# Altium schematic sheet ASV3.5-2 54V Isolator.SchDoc("ASV3.5-2 54V Isolator")
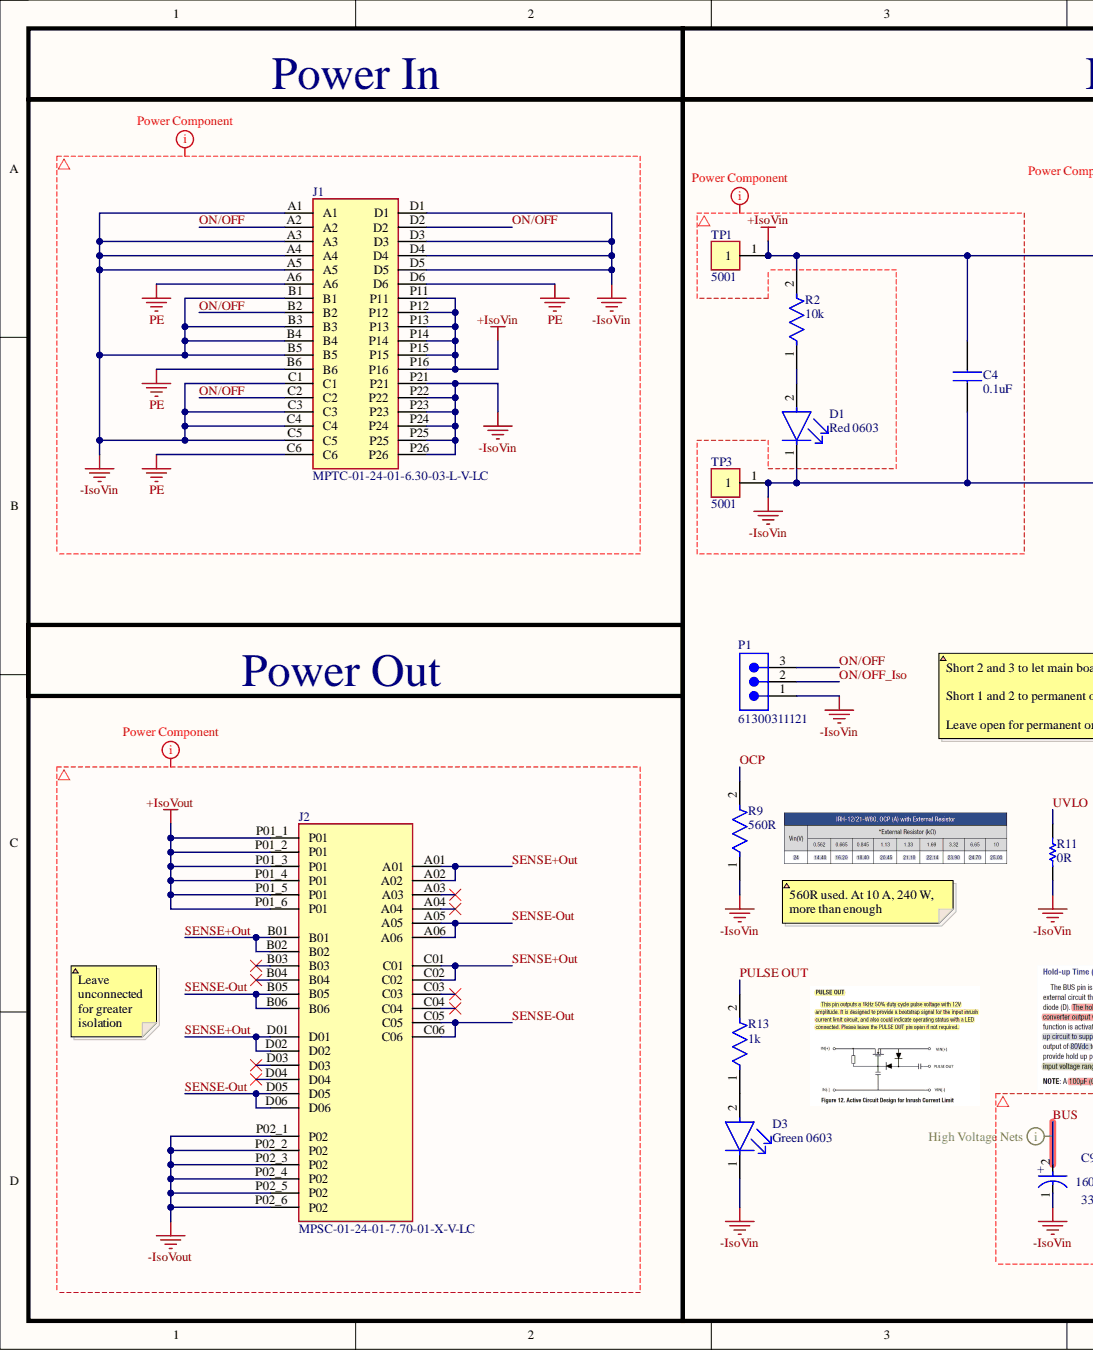
[diagram p0: ASV3.5-2 54V Isolator.SchDoc, left]
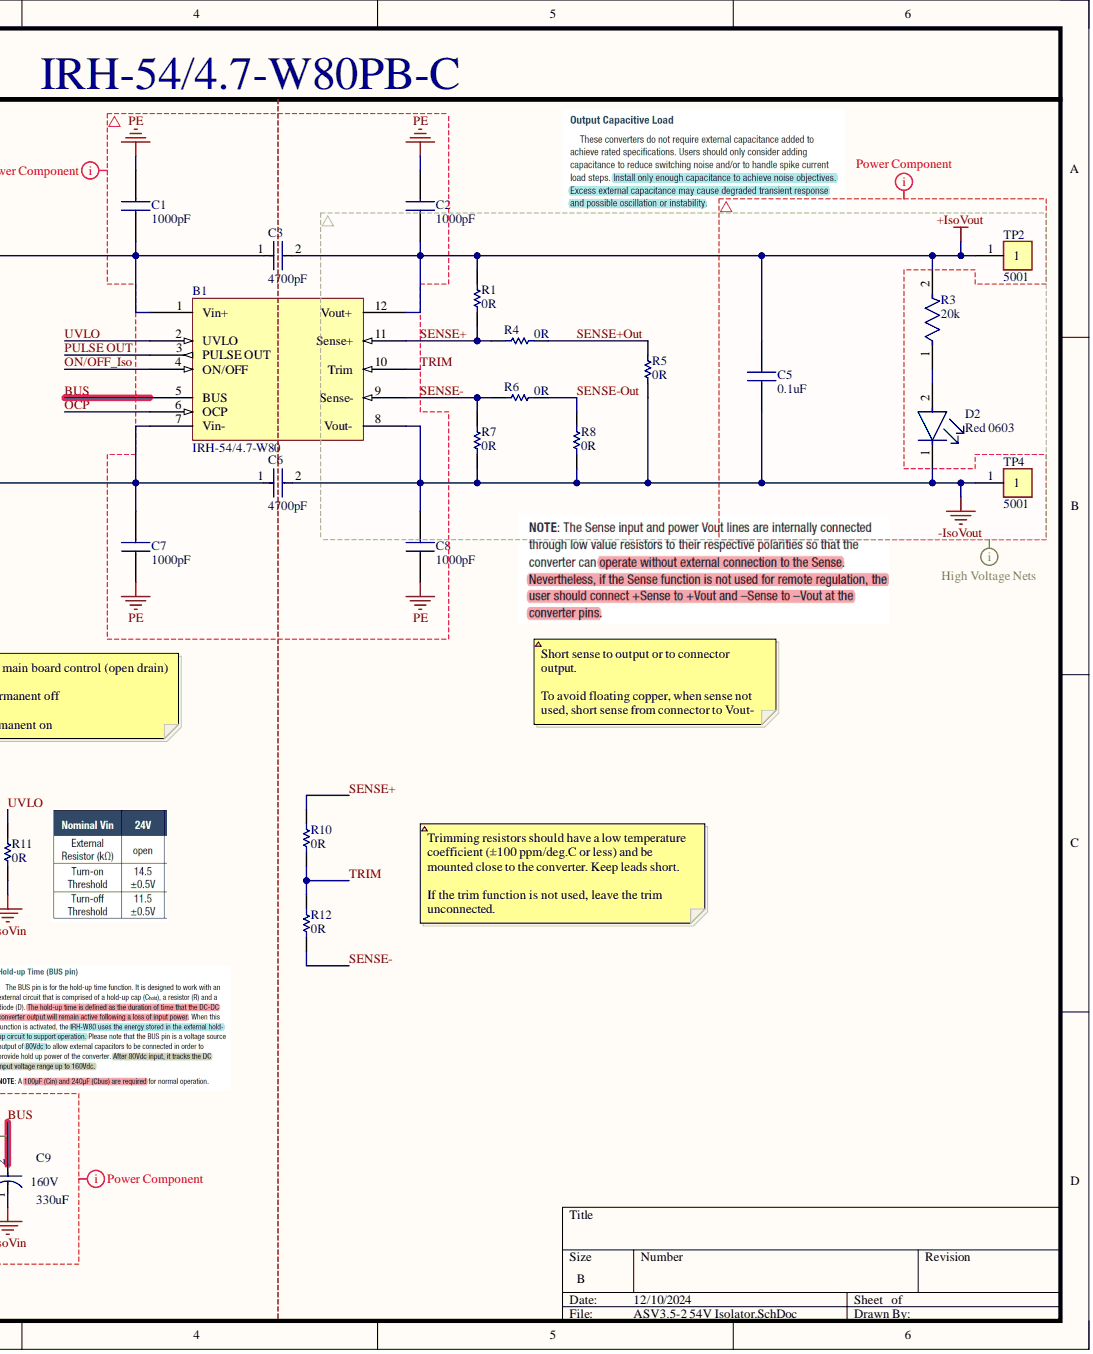
[diagram p1: ASV3.5-2 54V Isolator.SchDoc, right]

## Parts
B1  IRH-54/4.7-W80
C1  1000pF
C2  1000pF
C3  4700pF
C4  0.1uF
C5  0.1uF
C6  4700pF
C7  1000pF
C8  1000pF
C9  330uF  Voltage: 160V
D1  Red 0603  Case Code (Imperial): 0603  Color: Red
D2  Red 0603  Case Code (Imperial): 0603  Color: Red
D3  Green 0603  Color: Green
J1  MPTC-01-24-01-6.30-03-L-V-LC
J2  MPSC-01-24-01-7.70-01-X-V-LC
P1  61300311121
R1  0R
R2  10k
R3  20k
R4  0R
R5  0R
R6  0R
R7  0R
R8  0R
R9  560R
R10  0R
R11  0R
R12  0R
R13  1k
TP1  5001
TP2  5001
TP3  5001
TP4  5001

## Nets
+IsoVin: B1.1(Vin+) C1.1 C3.1 C4.2 J1.P11 J1.P12 J1.P13 J1.P14 J1.P15 J1.P16 R2.2 TP1.1
+IsoVout: B1.12(Vout+) C2.1 C3.2 C5.2 J2.P01_1(P01) J2.P01_2(P01) J2.P01_3(P01) J2.P01_4(P01) J2.P01_5(P01) J2.P01_6(P01) R1.2 R3.2 TP2.1
-IsoVin: B1.7(Vin-) C4.1 C6.1 C7.2 C9.1 D1.1 D3.1 J1.A1 J1.A3 J1.A4 J1.A5 J1.B1 J1.B3 J1.B4 J1.B5 J1.C1 J1.C3 J1.C4 J1.C5 J1.D1 J1.D3 J1.D4 J1.D5 J1.P21 J1.P22 J1.P23 J1.P24 J1.P25 J1.P26 P1.1 R9.1 R11.2 TP3.1
-IsoVout: B1.8(Vout-) C5.1 C6.2 C8.2 D2.1 J2.P02_1(P02) J2.P02_2(P02) J2.P02_3(P02) J2.P02_4(P02) J2.P02_5(P02) J2.P02_6(P02) R5.1 R7.2 R8.1 TP4.1
PE: C1.2 C2.2 C7.1 C8.1 J1.A6 J1.B6 J1.C6 J1.D6
BUS: B1.5(BUS) C9.2
OCP: B1.6(OCP) R9.2
ON/OFF: J1.A2 J1.B2 J1.C2 J1.D2 P1.3
ON/OFF_Iso: B1.4(ON/OFF) P1.2
PULSE OUT: B1.3(PULSE_OUT) R13.2
SENSE+: B1.11(Sense+) R1.1 R4.1 R10.1
SENSE+Out: J2.A01 J2.A02 J2.B01 J2.B02 J2.C01 J2.C02 J2.D01 J2.D02 R4.2 R5.2
SENSE-: B1.9(Sense-) R6.1 R7.1 R12.2
SENSE-Out: J2.A05 J2.A06 J2.B05 J2.B06 J2.C05 J2.C06 J2.D05 J2.D06 R6.2 R8.2
TRIM: B1.10(Trim) R10.2 R12.1
UVLO: B1.2(UVLO) R11.1
NetD1_2: D1.2 R2.1
NetD2_2: D2.2 R3.1
NetD3_2: D3.2 R13.1

Not connected: J2 A03 A04 B03 B04 C03 C04 D03 D04

## Library data of the parts
B1 = CMP-00062-2: Murata IRH-54/4.7-W80, 16-160VDC to 54VDC 4.7A, 250W,  isolated regulated converter; Component Kind: Standard; ComponentLink1Description: element14; ComponentLink1URL: https://sg.element14.com/murata-power-solutions/irh-54-4-7-w80pb-c/dc-dc-converter-54v-4-7a/dp/4132834?CMP=i-55c5-00001621; ComponentLink2Description: IRH-54/4.7-W80; ComponentLink2URL: https://www.farnell.com/datasheets/3625925.pdf; Library Name: BumbleBee Autonomous Systems - NUS
C1 C2 C7 C8 = CMP-123-000008-2: GR442QR73D102KW01L. MURATA - GR442QR73D102KW01L - CAPACITOR, MLCC, X7R, 1000PF, 2KV, 1808; Manufacturer_Name: Murata Electronics; Geometry.Height: 1.5mm; Component Kind: Standard; Datasheet Link: https://componentsearchengine.com/Datasheets/2/GR442QR73D102KW01L.pdf; Design Item ID: GR442QR73D102KW01L; Manufacturer_Part_Number: GR442QR73D102KW01L; Mouser Part Number: 81-GR442QR73D102KW01; Mouser Price/Stock: https://www.mouser.co.uk/ProductDetail/Murata-Electronics/GR442QR73D102KW01L?qs=1XGAtBqYNz74JcxvF1DQuw%3D%3D; Source: GR442QR73D102KW01L.SchLib; Library Name: BumbleBee Autonomous Systems - NUS
C3 C6 = CMP-06035-046982-1: DE1E3RA472MA4BQ01F. Cap Ceramic Disc 4700pF 20% 500VAC Thru-Hole 14.0x6.0x10.0 Bulk; Component Kind: Standard; Library Name: Altium Content Vault
C4 C5 = CMP-123-000009-2: GRM32DR72H104KW10L. Multilayer Ceramic Capacitors MLCC - SMD/SMT 1210 0.1uF 500volt X7R 10%; Manufacturer_Name: Murata Electronics; Geometry.Height: 2.2mm; Component Kind: Standard; Datasheet Link: https://componentsearchengine.com/Datasheets/1/GRM32DR72H104KW10L.pdf; Design Item ID: GRM32DR72H104KW10L; Manufacturer_Part_Number: GRM32DR72H104KW10L; Mouser Part Number: 81-GRM32DR72H104KW1L; Mouser Price/Stock: https://www.mouser.com/Search/Refine.aspx?Keyword=81-GRM32DR72H104KW1L; Source: GRM32DR72H104KW10L.SchLib; Library Name: BumbleBee Autonomous Systems - NUS
C9 = CMP-05427-000720-1: EEUEE2C331. Aluminum Electrolytic Capacitors (Radial Lead Type) 330uF ±20% 160V; Dissipation Factor: 0.15%; Lead Diameter: 0.8mm; Leakage Current: 3.178mA; Life (Hours): 10000Hour; Polarity: Polar; Ripple Current: 2.6A; Capacitance: 330uF; Case/Package: Radial; Component Kind: Standard; Construction: RADIAL; Contact Plating: Tin; Diameter: 18mm; Height: 31.5mm; Height - Seated (Max): 1.319inch; Lead Free: Lead Free; Lead Pitch: 7.5mm; Length: 31.5mm; Library Name: Altium Content Vault; Max Operating Temperature: 105°C; Min Operating Temperature: -25°C; Mount: Through Hole; Number of Pins: 2; Package Quantity: 50; Packaging: Bulk; Radiation Hardening: No; REACH SVHC: No SVHC; Ripple Current (AC): 2.6A; RoHS Compliant: Yes; Series: EE; Termination: Radial; Tolerance: 20%; Voltage Rating: 160V; Voltage Rating (DC): 160V; Weight: 0.01lb; Width: 0.5inch
D1 D2 = CMP-00010-2: Led Red Diffused 0603 SMD; Manufacturer Part No.: 150060RS55040; Dominant Wavelength: 624nm; Forward Voltage: 2V; Lens Size: 0.8mm; Lens Style: Flat; Luminous Intensity: 90mcd; Test Current: 20mA; Viewing Angle: 140°; Case Code (Metric): 1608; Case/Package: 0603; Component Kind: Standard; Datasheet: https://www.farnell.com/datasheets/2621644.pdf?src-supplier=Farnell; Height: 0.5mm; Length: 1.6mm; Lens Transparency: Diffused; Mounting Technology: Surface Mount; Packaging: Cut Tape; RoHS Compliant: Yes; Series: WL-SMDC; Width: 0.8mm; Library Name: BumbleBee Autonomous Systems - NUS
D3 = CMP-00011-1: Led, Green, 570 Nm, 2 V, 30 Ma, 40 Mcd Rohs Compliant: Yes; Manufacturer Part No.: 150060VS75000; Dominant Wavelength: 570nm; Forward Current: 20mA; Forward Voltage: 2V; Lens Color: Colorless; Lens Size: 0.7mm; Lens Style: Rectangular,Flat; Lens Transparency: Clear; Luminous Intensity: 40mcd; Power Rating: 72mW; Reverse Voltage (DC): 5V; Viewing Angle: 140°; Case Code (Imperial): 0603; Case Code (Metric): 1608; Case/Package: 0603; Component Kind: Standard; Height: 0.8mm; Illumination Color: Green; Length: 1.6mm; Max Operating Temperature: 85°C; Min Operating Temperature: -40°C; Mounting Technology: Surface Mount; Package Quantity: 4000; Packaging: Cut Tape; Pins: 2; RoHS Compliant: Yes; Series: WL-SMCW; Test Current: 20mA; Weight: 0.000882oz; Width: 0.8mm; Library Name: BumbleBee Autonomous Systems - NUS
J1 = CMP-062-000002-2: Connector; Manufacturer_Name: SAMTEC; Component Kind: Standard; Datasheet Link: http://suddendocs.samtec.com/prints/mptc-xx-xx-xx-6.30-xx-x-v-xx-mkt.pdf; Design Item ID: MPTC-01-24-01-6.30-03-L-V-LC; Height: 11.38mm; Manufacturer_Part_Number: MPTC-01-24-01-6.30-03-L-V-LC; Source: SamacSys.SchLib; System: Connector; Library Name: BumbleBee Autonomous Systems - NUS
J2 = CMP-141-000018-2: Vertical Mini-Power W/ Signal Socket; System: Connector; Availability: Unavailable; Component Kind: Standard; Component Type: Standard; Design Item ID: MPSC-01-24-01-7.70-01-X-V-LC; MP: MPSC-01-24-01-7.70-01-X-V-LC; Package: None; Pin Count: 36; Price: None; Source: MPSC-01-24-01-7.70-01-X-V-LC.SchLib; Library Name: BumbleBee Autonomous Systems - NUS
P1 = CMP-1502-01062-2: NexarPartId: 32855521; Connector Type: Male Pin; Contact Resistance: 20mΩ; Current Rating: 3A; Gender: Male; Number of Contacts: 3; Operating Supply Voltage: 250V; Pitch: 2.54mm; Color: Black; Component Kind: Standard; Contact Material: Copper; Contact Mating Length: 5.9944mm; Contact Plating: Gold; Height: 8.94mm; Lead Free: Lead Free; Lead Pitch: 2.54mm; Library Name: Altium Content Vault; Max Operating Temperature: 105°C; Min Operating Temperature: -40°C; Mount: Through Hole; Number of Pins: 3; Number of Positions: 3; Number of Rows: 1; Orientation: Vertical; Packaging: Bulk; REACH SVHC: Unknown; RoHS: Compliant; Termination: Solder; Voltage Rating: 250V
R1 R4-R8 R10-R12 = CMP-00053-1: RES SMD 0 OHM JUMPER 1/10W 0603; Max Power Dissipation: 100mW; Resistance Tolerance: 5%; Temperature Coefficient: 200ppm/°C; Tolerance: 1%; Value: 0mR; Voltage Rating: 50V; Case Code (Imperial): 0603; Case Code (Metric): 1608; Case/Package: 0603; Component Kind: Standard; Construction: Rectangular; Contact Plating: Tin,Matte; Depth: 0.8mm; Features: Moisture Resistant; Height: 0.45mm; Lead Free: Lead Free; Length: 1.6mm; Max Operating Temperature: 155°C; Military Standard: Not; Min Operating Temperature: -55°C; Number of Terminations: 2; Package Quantity: 5000; Packaging: Tape and Reel; Pins: 2; Power Rating: 100mW; Reach SVHC Compliant: Unknown; Resistor Type: Thick Film; RoHS Compliant: Yes; Series: RC; Technology: THICK FILM; Termination: SMD/SMT; Voltage Rating (DC): 50V; Width: 0.8mm; Library Name: BumbleBee Autonomous Systems - NUS
R2 = CMP-05422-000107-1: ERJ-PB3B1002V. RES SMD 10K OHM 0.1% 1/5W 0603; Max Power Dissipation: 200mW; Resistance: 10kR; Temperature Coefficient: 50ppm/°C; Case Code (Imperial): 0603; Case Code (Metric): 1608; Case/Package: 0603; Component Kind: Standard; Features: Automotive AEC-Q200; Height - Seated (Max): 0.022inch; Length: 0.063inch; Library Name: Altium Content Vault; Max Operating Temperature: 155°C; Min Operating Temperature: -55°C; Number of Terminations: 2; Packaging: Tape and Reel; Resistor Type: Thick Film; Tolerance: 0.1%; Width: 0.032inch
R3 = CMP-147-000036-2: ERJ-PB3B2002V. Fixed Resistor, Metal Glaze/thick Film, 0.2W, 20000ohm, 150V, 0.1% +/-Tol, 50ppm/Cel, Surface Mount, 0603; Component Kind: Standard; Datasheet Link: https://www.mouser.sg/datasheet/2/315/AOA0000C328-1530132.pdf; Library Name: BumbleBee Autonomous Systems - NUS
R9 = CMP-147-000037-1: ERJ-PB3B5600V. Res SMD 560 Ohm 0.1% 1/5W 0603; Component Kind: Standard; Library Name: BumbleBee Autonomous Systems - NUS
R13 = CMP-1659-00009-5: RC0603FR-071KL. RES 1K OHM 1% 1/10W 0603; Max Power Dissipation: 100mW; Resistance: 1kR; Temperature Coefficient: 100ppm/°C; Voltage Rating: 50V; Case Code (Imperial): 0603; Case Code (Metric): 1608; Case/Package: 0603; Component Kind: Standard; Construction: Rectangular; Contact Plating: Tin; Depth: 0.8mm; Features: Moisture Resistant; Height: 0.45mm; Lead Free: Lead Free; Length: 1.6mm; Library Name: Altium Content Vault; Max Operating Temperature: 155°C; Military Standard: Not; Min Operating Temperature: -55°C; Number of Terminations: 2; Packaging: Tape and Reel; Power Rating: 100mW; Radiation Hardening: No; REACH SVHC: No SVHC; Resistance Tolerance: 1%; Resistor Type: Thick Film; RoHS Compliant: Yes; Series: RC; Size Code: 0603; Technology: THICK FILM; Termination: SMD/SMT; Tolerance: 1%
TP1-TP4 = CMP-2000-05181-2: PC TEST POINT MINIATURE BLACK; Body Material: Bronze; Hole Diameter: 1.6mm; Inside Diameter: 1.27mm; Insulation: Insulated; Number of Contacts: 1; Outside Diameter: 2.54mm; Plating: Silver; Color: Black; Component Kind: Standard; Contact Material: Bronze; Contact Plating: Silver; Diameter: 2.54mm; Flammability Rating: UL94 V-0; Height: 0.3inch; Housing Color: Black; Insulation Material: Nylon; Lead Free: Lead Free; Length: 7.62mm; Library Name: Altium Content Vault; Material: Nylon; Mount: Through Hole; Number of Ports: 1; Orientation: Straight; Package Quantity: 100; Packaging: Bulk; REACH SVHC: No SVHC; RoHS Compliant: Yes; Series: THM; Termination: Through Hole
